# Altium schematic sheet SPI and enables.SchDoc
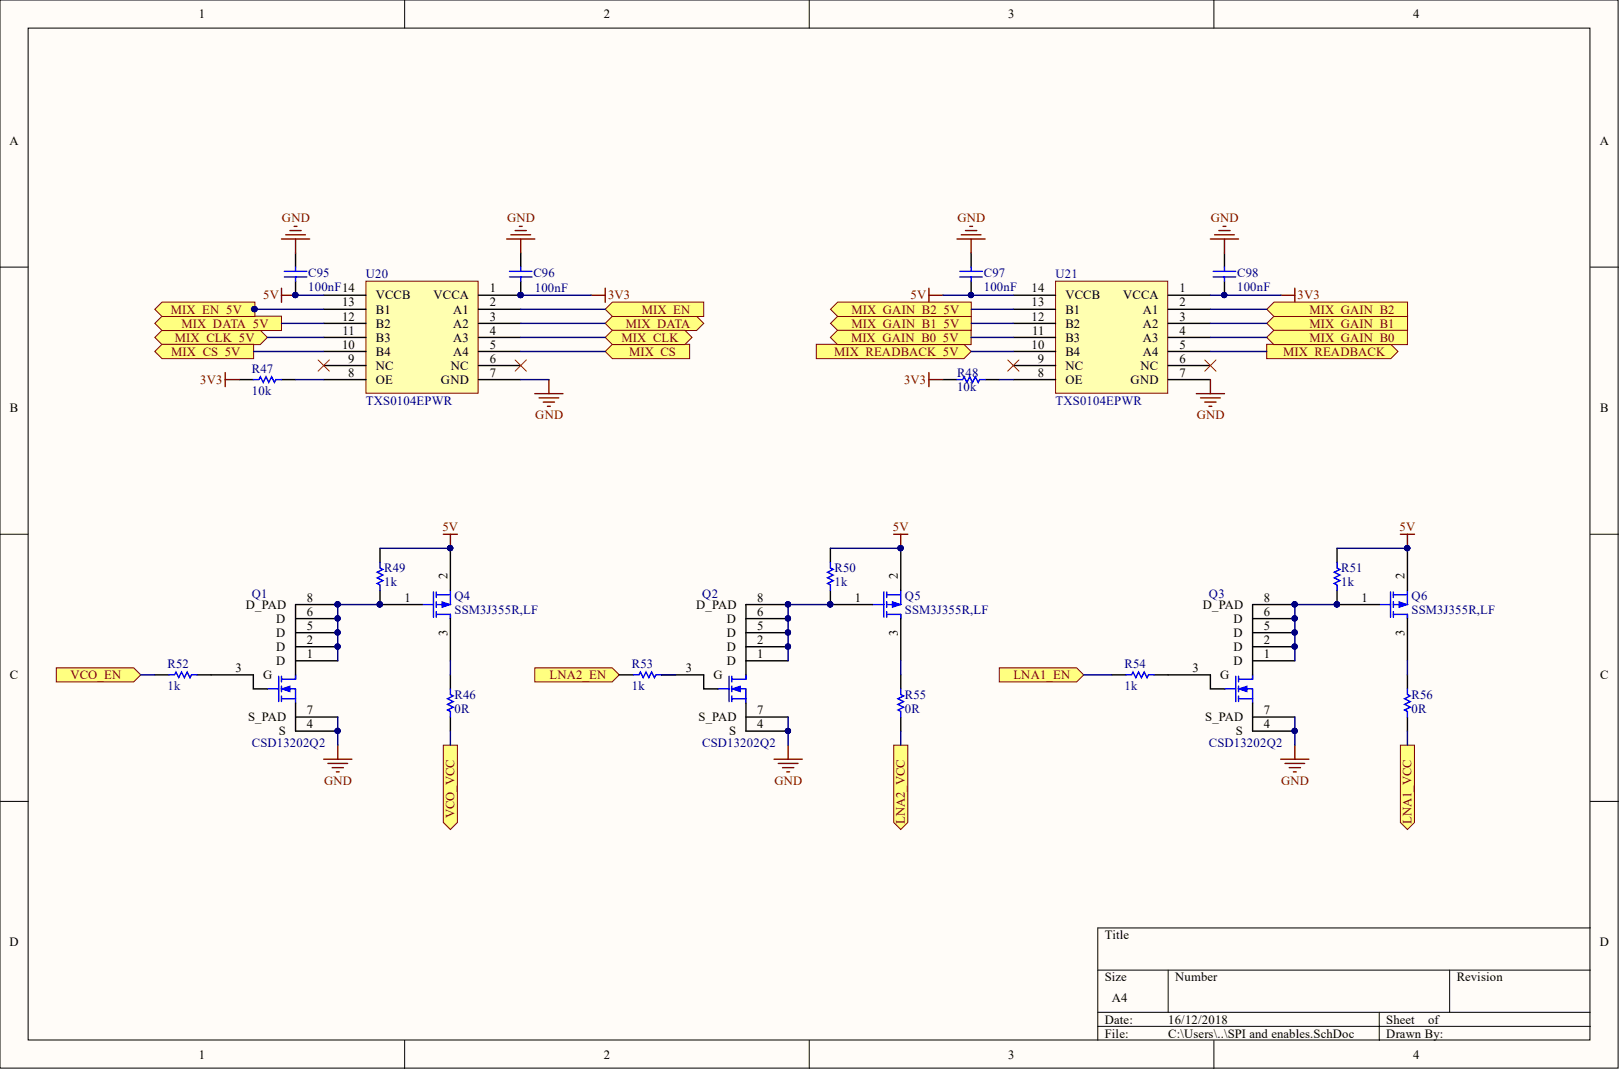
[diagram p0: SPI and enables.SchDoc]

## Parts
C95  100nF  [0603 Cap]
C96  100nF  [0603 Cap]
C97  100nF  [0603 Cap]
C98  100nF  [0603 Cap]
Q1  CSD13202Q2  [8-QFN]
Q2  CSD13202Q2  [8-QFN]
Q3  CSD13202Q2  [8-QFN]
Q4  SSM3J355R,LF  [SOT95P240X80-3M]
Q5  SSM3J355R,LF  [SOT95P240X80-3M]
Q6  SSM3J355R,LF  [SOT95P240X80-3M]
R46  0R  [0603 Res]
R47  10k  [0603 Res]
R48  10k  [0603 Res]
R49  1k  [0603 Res]
R50  1k  [0603 Res]
R51  1k  [0603 Res]
R52  1k  [0603 Res]
R53  1k  [0603 Res]
R54  1k  [0603 Res]
R55  0R  [0603 Res]
R56  0R  [0603 Res]
U20  TXS0104EPWR  [14-SOIC]
U21  TXS0104EPWR  [14-SOIC]

## Ports
LNA1_EN, LNA1_VCC, LNA2_EN, LNA2_VCC, MIX_CLK, MIX_CLK_5V, MIX_CS, MIX_CS_5V, MIX_DATA, MIX_DATA_5V, MIX_EN, MIX_EN_5V, MIX_GAIN_B0, MIX_GAIN_B0_5V, MIX_GAIN_B1, MIX_GAIN_B1_5V, MIX_GAIN_B2, MIX_GAIN_B2_5V, MIX_READBACK, MIX_READBACK_5V, VCO_EN, VCO_VCC

## Nets
3V3: C96.1 C98.1 R47.2 R48.2 U20.1(VCCA) U21.1(VCCA)
5V: C95.1 C97.1 Q4.2 Q5.2 Q6.2 R49.2 R50.2 R51.2 U20.14(VCCB) U21.14(VCCB)
GND: C95.2 C96.2 C97.2 C98.2 Q1.4(S) Q1.7(S_PAD) Q2.4(S) Q2.7(S_PAD) Q3.4(S) Q3.7(S_PAD) U20.7(GND) U21.7(GND)
LNA1_EN: R54.2 ; port LNA1_EN
LNA1_VCC: R56.1 ; port LNA1_VCC
LNA2_EN: R53.2 ; port LNA2_EN
LNA2_VCC: R55.1 ; port LNA2_VCC
MIX_CLK: U20.4(A3) ; port MIX_CLK
MIX_CLK_5V: U20.11(B3) ; port MIX_CLK_5V
MIX_CS: U20.5(A4) ; port MIX_CS
MIX_CS_5V: U20.10(B4) ; port MIX_CS_5V
MIX_DATA: U20.3(A2) ; port MIX_DATA
MIX_DATA_5V: U20.12(B2) ; port MIX_DATA_5V
MIX_EN: U20.2(A1) ; port MIX_EN
MIX_EN_5V: U20.13(B1) ; port MIX_EN_5V
MIX_GAIN_B0: U21.4(A3) ; port MIX_GAIN_B0
MIX_GAIN_B0_5V: U21.11(B3) ; port MIX_GAIN_B0_5V
MIX_GAIN_B1: U21.3(A2) ; port MIX_GAIN_B1
MIX_GAIN_B1_5V: U21.12(B2) ; port MIX_GAIN_B1_5V
MIX_GAIN_B2: U21.2(A1) ; port MIX_GAIN_B2
MIX_GAIN_B2_5V: U21.13(B1) ; port MIX_GAIN_B2_5V
MIX_READBACK: U21.5(A4) ; port MIX_READBACK
MIX_READBACK_5V: U21.10(B4) ; port MIX_READBACK_5V
VCO_EN: R52.2 ; port VCO_EN
VCO_VCC: R46.1 ; port VCO_VCC
NetQ1_1: Q1.1(D) Q1.2(D) Q1.5(D) Q1.6(D) Q1.8(D_PAD) Q4.1 R49.1
NetQ1_3: Q1.3(G) R52.1
NetQ2_1: Q2.1(D) Q2.2(D) Q2.5(D) Q2.6(D) Q2.8(D_PAD) Q5.1 R50.1
NetQ2_3: Q2.3(G) R53.1
NetQ3_1: Q3.1(D) Q3.2(D) Q3.5(D) Q3.6(D) Q3.8(D_PAD) Q6.1 R51.1
NetQ3_3: Q3.3(G) R54.1
NetQ4_3: Q4.3 R46.2
NetQ5_3: Q5.3 R55.2
NetQ6_3: Q6.3 R56.2
NetR47_1: R47.1 U20.8(OE)
NetR48_1: R48.1 U21.8(OE)

Not connected: U20 6(NC) 9(NC); U21 6(NC) 9(NC)

## Library data of the parts
C95-C98 = C_0603: CAP CER 16V X7R 0603; Material: Ceramic; Tolerance: 10; Voltage: 16; Case/Package: 0603; Case Code (Imperial): 0603; Case Code (Metric): 1608; Component Kind: Standard; Composition: Ceramic; Depth: 0.8; Dielectric: X7R; Height: 0.8; Length: 1.6; Library Name: Componentes_base.SchLib; Mount: Surface Mount; Termination: SMD; Thickness: 0.035; Voltage Rating: 16; Voltage Rating (DC): 16; Width: 0.8
Q1-Q3 = CSD13202Q2: MOSFET N-CH 12V 76A 6SON; Manufacturer: Texas Instruments; Current - Continuous Drain (Id) @ 25°C: 22A (Ta); Drive Voltage (Max Rds On,  Min Rds On): 2.5V, 4.5V; FET Type: N-Channel; Power Dissipation (Max): 2.7W (Ta); Rds On (Max) @ Id, Vgs: 9.3 mOhm @ 5A, 4.5V; Vgs (Max): ±8V; Vgs(th) (Max) @ Id: 1.1V @ 250µA; Category: Discrete Semiconductor Products; Component Kind: Standard; ComponentLink1Description: http://www.ti.com/lit/ds/symlink/csd13202q2.pdf; ComponentLink1URL: http://www.ti.com/lit/ds/symlink/csd13202q2.pdf; FET Feature: -; Library Name: Schlib1.SchLib; Manufacturer Part Number: CSD13202Q2; Mounting Type: Surface Mount; Operating Temperature: -55°C ~ 150°C (TJ); Package / Case: 6-VDFN Exposed Pad; Packaging: Cut Tape (CT); Part Status: Active; Pricing 1: 1=0.58, 10=0.489, 100=0.3671, 500=0.26922, 1000=0.20803 (EUR); RoHS: RoHS Compliant; Stock 1: 166239; Supplier 1: Digi-Key; Supplier Device Package: 6-WSON (2x2); Supplier Part Number 1: 296-38911-1-ND; Technology: MOSFET (Metal Oxide)
Q4-Q6 = SSM3J355R,LF: SMALL LOW ON RESISTANCE MOSFET; Manufacturer: Toshiba Semiconductor and Storage; Current - Continuous Drain (Id) @ 25°C: 6A (Ta); Drive Voltage (Max Rds On,  Min Rds On): 1.8V, 4.5V; FET Type: P-Channel; Power Dissipation (Max): 1W (Ta); Rds On (Max) @ Id, Vgs: 30.1 mOhm @ 4A, 4.5V; Vgs (Max): ±10V; Vgs(th) (Max) @ Id: 1V @ 1mA; Category: Discrete Semiconductor Products; Component Kind: Standard; ComponentLink1Description: https://toshiba.semicon-storage.com/info/docget.jsp?did=55743&prodName=SSM3J355R; ComponentLink1URL: https://toshiba.semicon-storage.com/info/docget.jsp?did=55743&prodName=SSM3J355R; FET Feature: -; Library Name: Schlib1.SchLib; Manufacturer Part Number: SSM3J355R,LF; Mounting Type: Surface Mount; Operating Temperature: 150°C (TJ); Package / Case: SOT-23-3 Flat Leads; Packaging: Cut Tape (CT); Part Status: Active; Pricing 1: 1=0.43, 10=0.298, 100=0.1958, 500=0.11568, 1000=0.089 (EUR); RoHS: RoHS Compliant; Stock 1: 3232; Supplier 1: Digi-Key; Supplier Device Package: SOT-23F; Supplier Part Number 1: SSM3J355RLFCT-ND; Technology: MOSFET (Metal Oxide)
R46-R56 = R_0603: SMD Resistor, 1%, 0.1W, 0603; Power Rating: 100; Tolerance: 1; Case/Package: 0603; Case Code (Imperial): 0603; Case Code (Metric): 1608; Component Kind: Standard; Depth: 0.8; Height: 0.45; Length: 1.6; Library Name: Componentes_base.SchLib; Mount: Surface Mount; Pins: 2; Termination: SMD; Width: 0.8
U20 U21 = TXS0104EPWR: IC TRNSLTR BIDIRECTIONAL 14TSSOP; Manufacturer: Texas Instruments; Channels per Circuit: 4; Data Rate: 24Mbps; Output Type: Open Drain, Push-Pull; Translator Type: Voltage Level; Base Part Number: TXS0104; Category: Integrated Circuits (ICs); Channel Type: Bidirectional; Component Kind: Standard; ComponentLink1Description: http://www.ti.com/lit/ds/symlink/txs0104e.pdf; ComponentLink1URL: http://www.ti.com/lit/ds/symlink/txs0104e.pdf; Features: Auto-Direction Sensing; Input Signal: -; Library Name: Schlib1.SchLib; Manufacturer Part Number: TXS0104EPWR; Mounting Type: Surface Mount; Operating Temperature: -40°C ~ 85°C (TA); Output Signal: -; Package / Case: 14-TSSOP (0.173", 4.40mm Width); Packaging: Cut Tape (CT); Part Status: Active; Pricing 1: 1=1.15, 10=1.027, 100=0.8009, 500=0.66168, 1000=0.52237 (EUR); RoHS: RoHS Compliant; Stock 1: 24531; Supplier 1: Digi-Key; Supplier Device Package: 14-TSSOP; Supplier Part Number 1: 296-20697-1-ND
